# Altium schematic sheet Internal 2017-2018.SchDoc
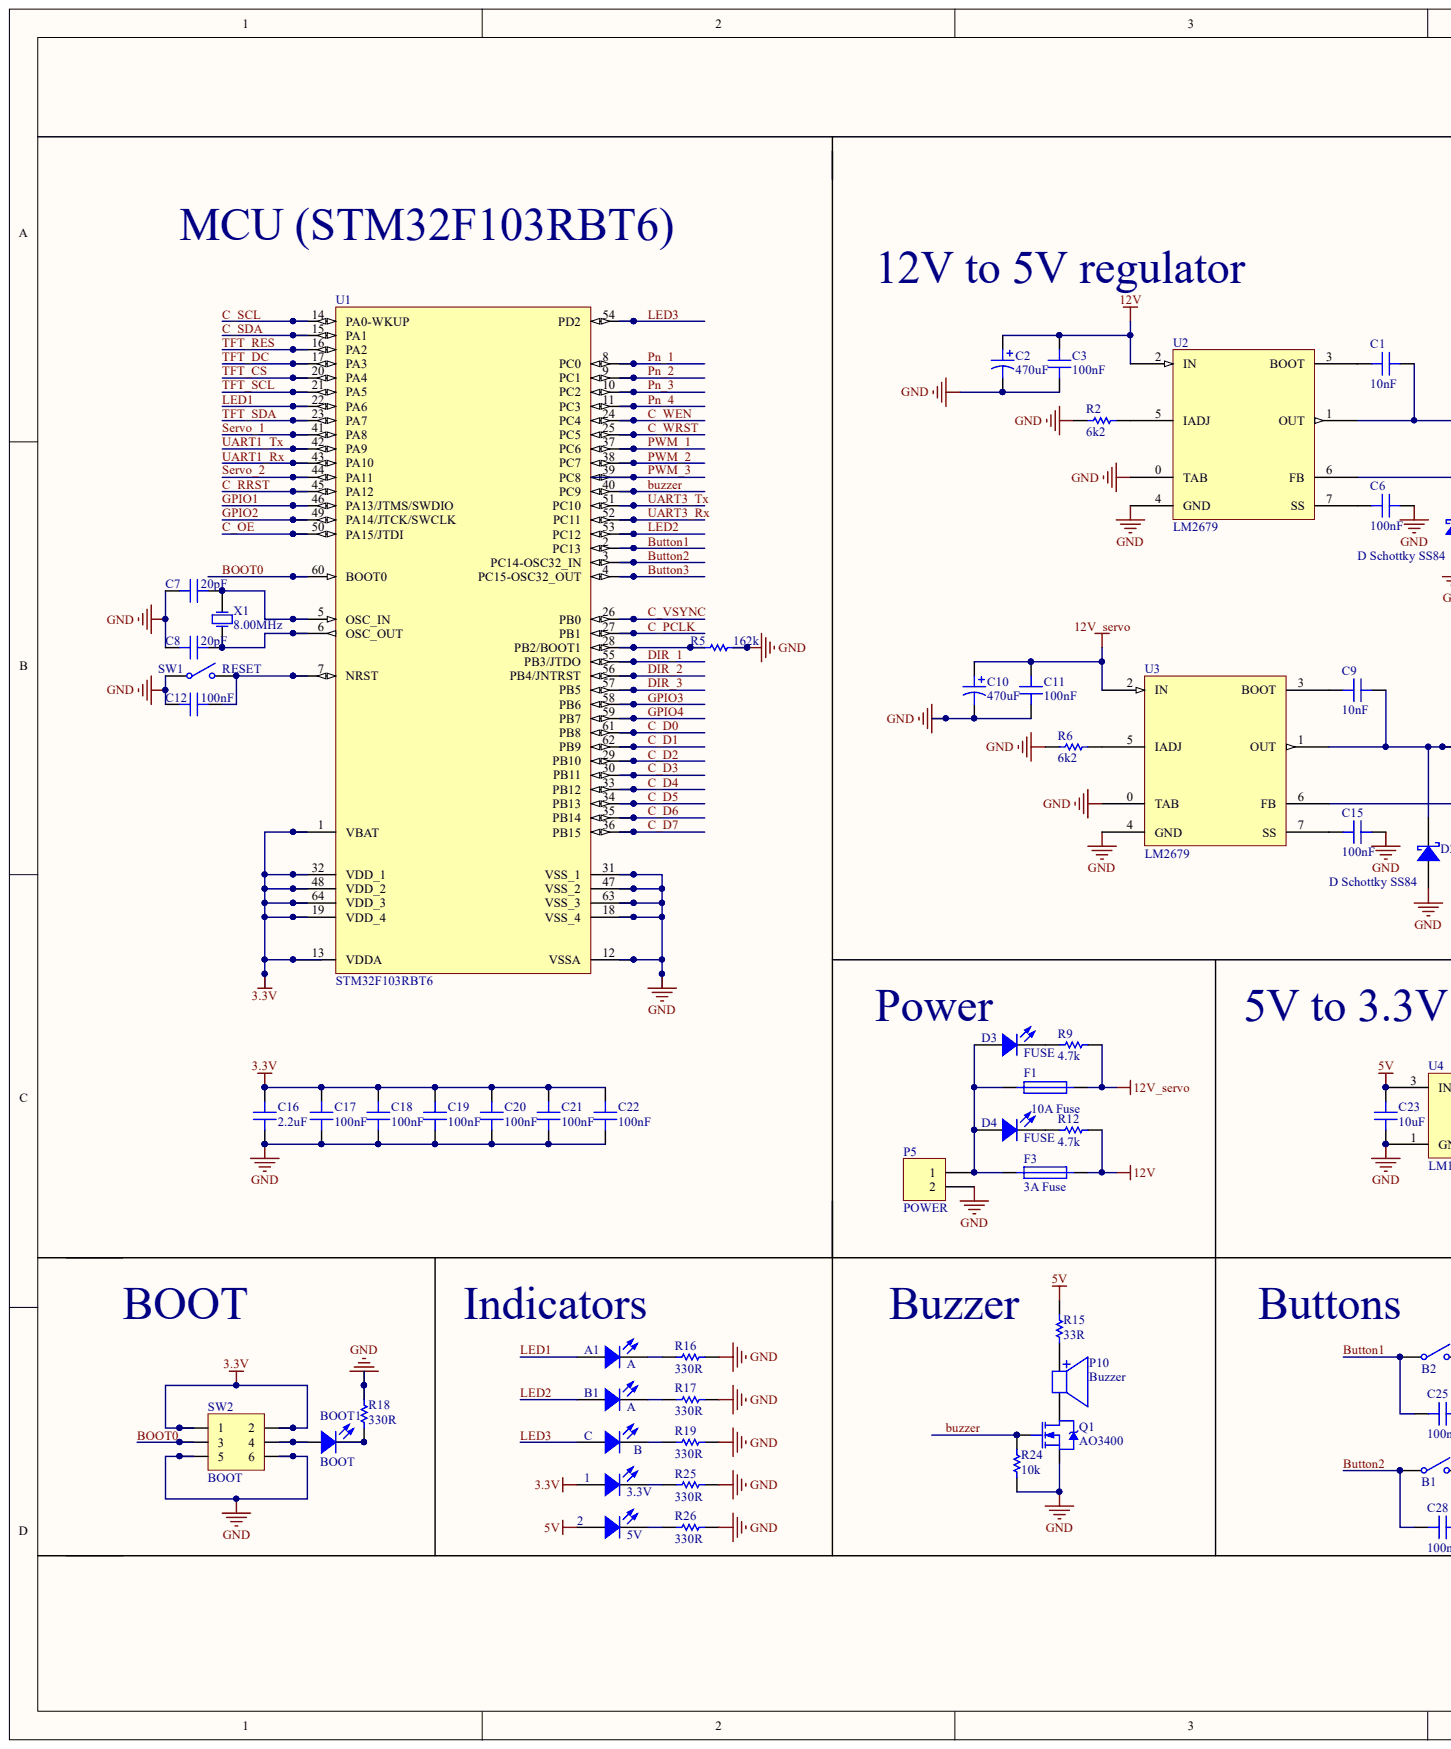
[diagram p0: Internal 2017-2018.SchDoc, left]
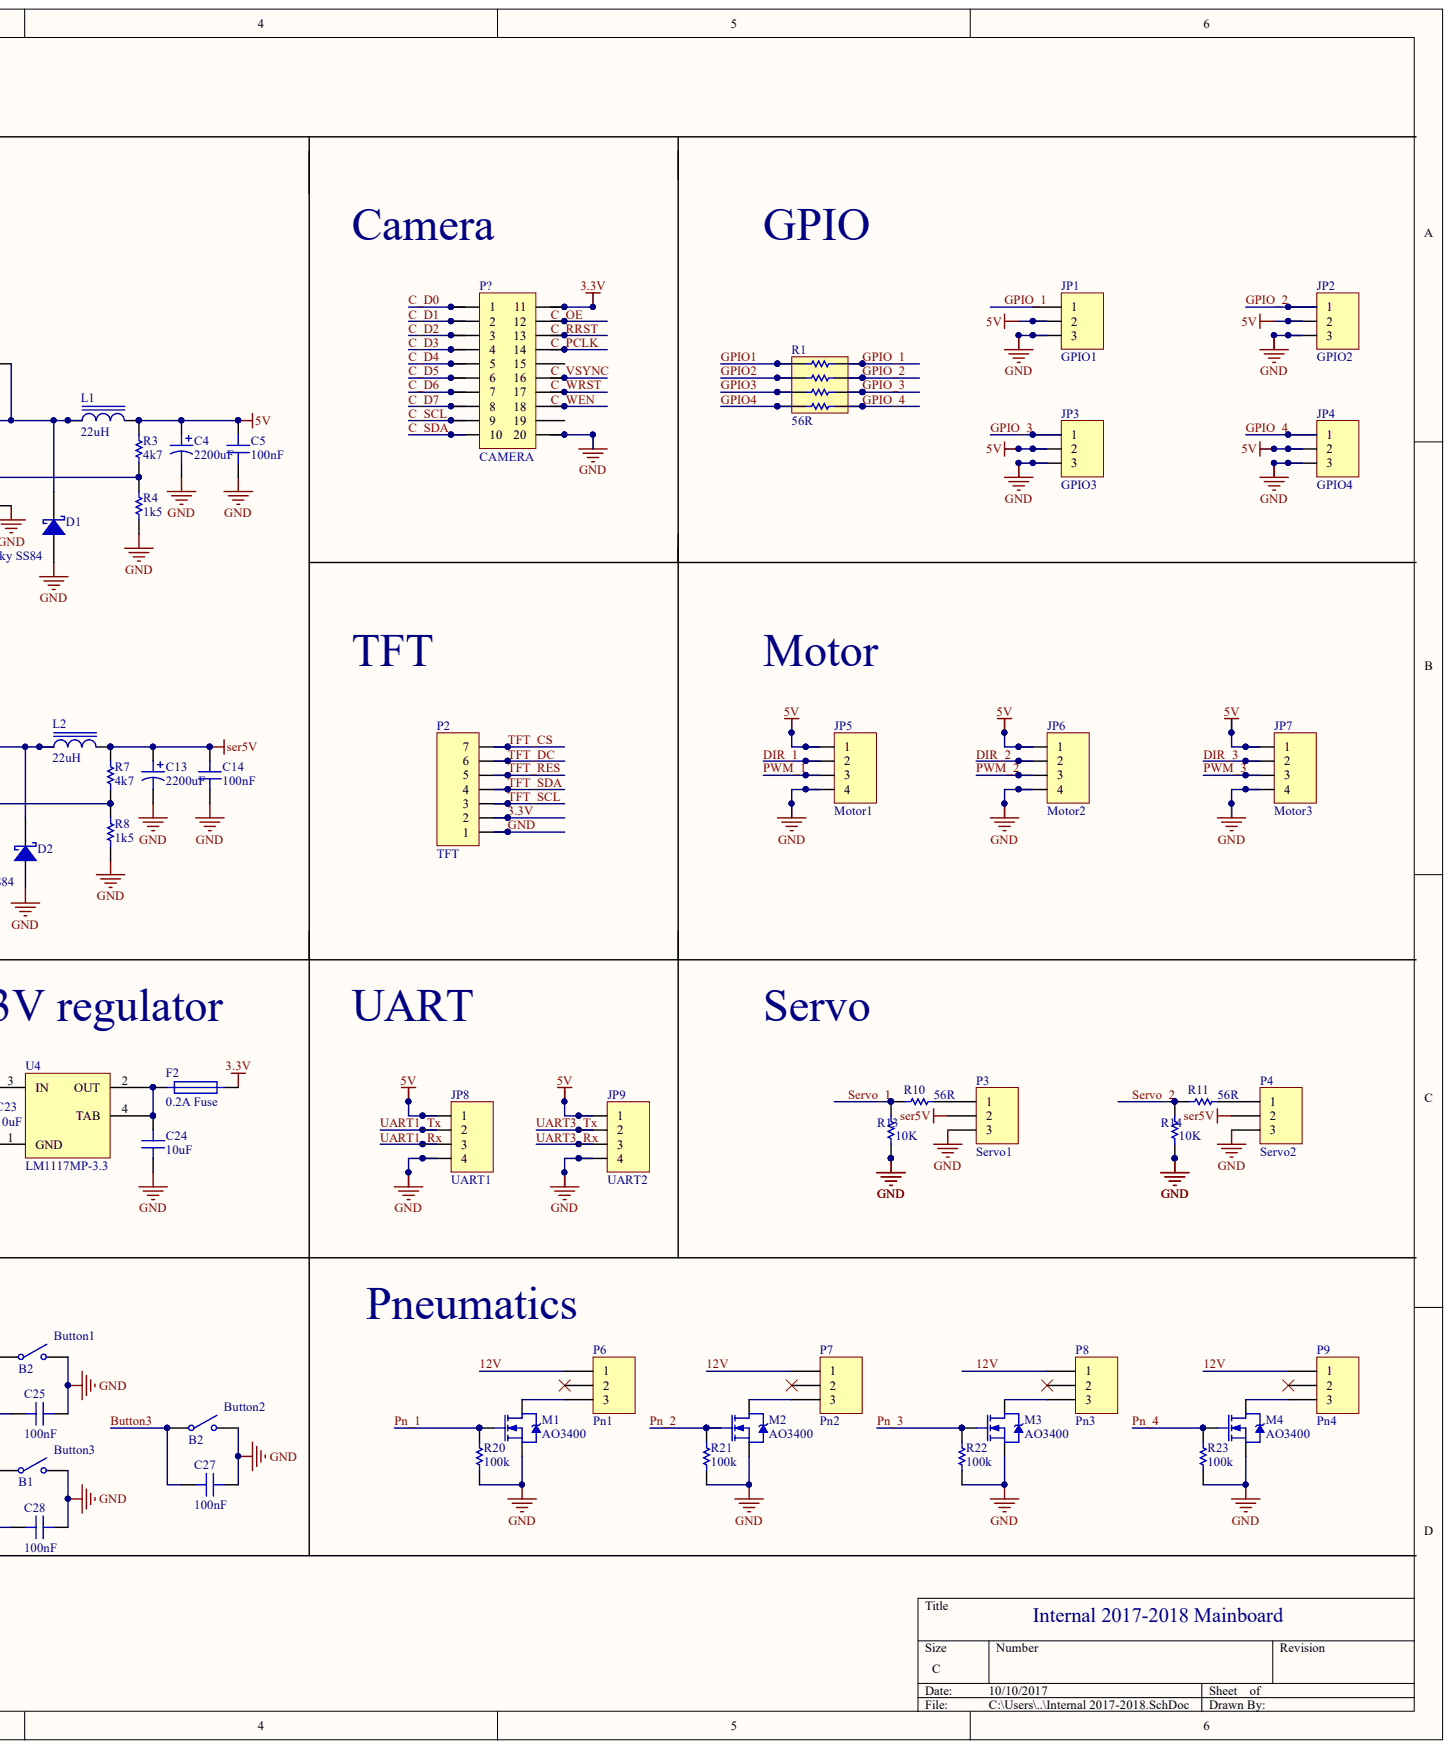
[diagram p1: Internal 2017-2018.SchDoc, right]

## Parts
1  3.3V  [D0805]
2  5V  [D0805]
A1  A  [D0805]
B1  A  [D0805]
BOOT1  BOOT  [D0805]
Button1  B2  [PUSH SWITCH]
Button2  B2  [PUSH SWITCH]
Button3  B1  [PUSH SWITCH]
C  B  [D0805]
C1  10nF  [CC2012-0805]
C2  470uF  [CAP10x12]
C3  100nF  [CC2012-0805]
C4  2200uF  [SV-H]
C5  100nF  [CC2012-0805]
C6  100nF  [CC2012-0805]
C7  20pF  [CC2012-0805]
C8  20pF  [CC2012-0805]
C9  10nF  [CC2012-0805]
C10  470uF  [CAP10x12]
C11  100nF  [CC2012-0805]
C12  100nF  [CC2012-0805]
C13  2200uF  [SV-H]
C14  100nF  [CC2012-0805]
C15  100nF  [CC2012-0805]
C16  2.2uF  [CC2012-0805]
C17  100nF  [CC2012-0805]
C18  100nF  [CC2012-0805]
C19  100nF  [CC2012-0805]
C20  100nF  [CC2012-0805]
C21  100nF  [CC2012-0805]
C22  100nF  [CC2012-0805]
C23  10uF  [CC2012-0805]
C24  10uF  [CC2012-0805]
C25  100nF  [CC2012-0805]
C27  100nF  [CC2012-0805]
C28  100nF  [CC2012-0805]
D1  D Schottky SS84  [SMC]
D2  D Schottky SS84  [SMC]
D3  FUSE  [D0805]
D4  FUSE  [D0805]
F1  10A Fuse  [FUSE SOCKET]
F2  0.2A Fuse  [CF3216-1206]
F3  3A Fuse  [FUSE SOCKET]
JP1  GPIO1  [LOCKEDHDR-3]
JP2  GPIO2  [LOCKEDHDR-3]
JP3  GPIO3  [LOCKEDHDR-3]
JP4  GPIO4  [LOCKEDHDR-3]
JP5  Motor1  [LOCKEDHDR-4]
JP6  Motor2  [LOCKEDHDR-4]
JP7  Motor3  [LOCKEDHDR-4]
JP8  UART1  [LOCKEDHDR-4]
JP9  UART2  [LOCKEDHDR-4]
L1  22uH  [CL3225-1210]
L2  22uH  [CL3225-1210]
M1  AO3400  [SOT-23]
M2  AO3400  [SOT-23]
M3  AO3400  [SOT-23]
M4  AO3400  [SOT-23]
P2  TFT  [HDR1X7]
P3  Servo1  [LOCKEDHDR-3]
P4  Servo2  [LOCKEDHDR-3]
P5  POWER  [T-CONNECTOR(M)]
P6  Pn1  [LOCKEDHDR-3]
P7  Pn2  [LOCKEDHDR-3]
P8  Pn3  [LOCKEDHDR-3]
P9  Pn4  [LOCKEDHDR-3]
P10  Buzzer  [BUZ-9.0]
P?  CAMERA  [HDR2X10_CEN]
Q1  AO3400  [SO-G3/Z3.3]
R1  56R  [ARC241-0603x4]
R2  6k2  [CR2012-0805]
R3  4k7  [CR2012-0805]
R4  1k5  [CR2012-0805]
R5  162k  [CR2012-0805]
R6  6k2  [CR2012-0805]
R7  4k7  [CR2012-0805]
R8  1k5  [CR2012-0805]
R9  4.7k  [CR2012-0805]
R10  56R  [CR2012-0805]
R11  56R  [CR2012-0805]
R12  4.7k  [CR2012-0805]
R13  10K  [CR2012-0805]
R14  10K  [CR2012-0805]
R15  33R  [CR2012-0805]
R16  330R  [CR2012-0805]
R17  330R  [CR2012-0805]
R18  330R  [CR2012-0805]
R19  330R  [CR2012-0805]
R20  100k  [CR2012-0805]
R21  100k  [CR2012-0805]
R22  100k  [CR2012-0805]
R23  100k  [CR2012-0805]
R24  10k  [CR2012-0805]
R25  330R  [CR2012-0805]
R26  330R  [CR2012-0805]
SW1  RESET  [PUSH SWITCH]
SW2  BOOT  [POWER SWITCH]
U1  STM32F103RBT6  [LQFP64_N]
U2  LM2679  [TO-263(7)]
U3  LM2679  [TO-263(7)]
U4  LM1117MP-3.3  [MP04A_N]
X1  8.00MHz  [BCY-W2/E4.7]

## Nets
5V: 2.1 C4.1 C5.1 C23.2 JP1.2 JP2.2 JP3.2 JP4.2 JP5.1 JP6.1 JP7.1 JP8.1 JP9.1 L1.2 R3.2 R15.2 U4.3(IN)
12V_SERVO: C10.1 C11.1 F1.2 R9.2 U3.2(IN)
SER5V: C13.1 C14.1 L2.2 P3.2 P4.2 R7.2
3.3V: 1.1 C16.1 C17.2 C18.2 C19.2 C20.2 C21.2 C22.2 F2.2 P2.2 P?.11 SW2.1 SW2.2 U1.1(VBAT) U1.13(VDDA) U1.19(VDD_4) U1.32(VDD_1) U1.48(VDD_2) U1.64(VDD_3)
12V: C2.1 C3.1 F3.2 P6.1 P7.1 P8.1 P9.1 R12.2 U2.2(IN)
BOOT0: SW2.3 U1.60(BOOT0)
BUTTON1: Button1.1 C25.2 U1.2(PC13)
BUTTON2: Button3.1 C28.2 U1.3(PC14-OSC32_IN)
BUTTON3: Button2.1 C27.2 U1.4(PC15-OSC32_OUT)
BUZZER: Q1.1 R24.2 U1.40(PC9)
C_D0: P?.1 U1.61(PB8)
C_D1: P?.2 U1.62(PB9)
C_D2: P?.3 U1.29(PB10)
C_D3: P?.4 U1.30(PB11)
C_D4: P?.5 U1.33(PB12)
C_D5: P?.6 U1.34(PB13)
C_D6: P?.7 U1.35(PB14)
C_D7: P?.8 U1.36(PB15)
C_OE: P?.12 U1.50(PA15/JTDI)
C_PCLK: P?.14 U1.27(PB1)
C_RRST: P?.13 U1.45(PA12)
C_SCL: P?.9 U1.14(PA0-WKUP)
C_SDA: P?.10 U1.15(PA1)
C_VSYNC: P?.16 U1.26(PB0)
C_WEN: P?.18 U1.24(PC4)
C_WRST: P?.17 U1.25(PC5)
DIR_1: JP5.2 U1.55(PB3/JTDO)
DIR_2: JP6.2 U1.56(PB4/JNTRST)
DIR_3: JP7.2 U1.57(PB5)
GND: Button1.2 Button2.2 Button3.2 C2.2 C3.2 C4.2 C5.2 C6.2 C7.1 C8.1 C10.2 C11.2 C12.2 C13.2 C14.2 C15.2 C16.2 C17.1 C18.1 C19.1 C20.1 C21.1 C22.1 C23.1 C24.1 C25.1 C27.1 C28.1 D1.1 D2.1 JP1.3 JP2.3 JP3.3 JP4.3 JP5.4 JP6.4 JP7.4 JP8.4 JP9.4 M1.2 M2.2 M3.2 M4.2 P2.1 P3.3 P4.3 P5.2 P?.20 Q1.2 R2.1 R4.1 R5.2 R6.1 R8.1 R13.1 R14.1 R16.2 R17.2 R18.2 R19.2 R20.2 R21.2 R22.2 R23.2 R24.1 R25.2 R26.2 SW1.1 SW2.5 SW2.6 U1.12(VSSA) U1.18(VSS_4) U1.31(VSS_1) U1.47(VSS_2) U1.63(VSS_3) U2.0(TAB) U2.4(GND) U3.0(TAB) U3.4(GND) U4.1(GND)
GPIO1: R1.1 U1.46(PA13/JTMS/SWDIO)
GPIO2: R1.3 U1.49(PA14/JTCK/SWCLK)
GPIO3: R1.5 U1.58(PB6)
GPIO4: R1.7 U1.59(PB7)
GPIO_1: JP1.1 R1.2
GPIO_2: JP2.1 R1.4
GPIO_3: JP3.1 R1.6
GPIO_4: JP4.1 R1.8
LED1: A1.1 U1.22(PA6)
LED2: B1.1 U1.53(PC12)
LED3: C.1 U1.54(PD2)
PN_1: M1.1 R20.1 U1.8(PC0)
PN_2: M2.1 R21.1 U1.9(PC1)
PN_3: M3.1 R22.1 U1.10(PC2)
PN_4: M4.1 R23.1 U1.11(PC3)
PWM_1: JP5.3 U1.37(PC6)
PWM_2: JP6.3 U1.38(PC7)
PWM_3: JP7.3 U1.39(PC8)
SERVO_1: R10.1 R13.2 U1.41(PA8)
SERVO_2: R11.1 R14.2 U1.44(PA11)
TFT_CS: P2.7 U1.20(PA4)
TFT_DC: P2.6 U1.17(PA3)
TFT_RES: P2.5 U1.16(PA2)
TFT_SCL: P2.3 U1.21(PA5)
TFT_SDA: P2.4 U1.23(PA7)
UART1_RX: JP8.3 U1.43(PA10)
UART1_TX: JP8.2 U1.42(PA9)
UART3_RX: JP9.3 U1.52(PC11)
UART3_TX: JP9.2 U1.51(PC10)
Net1_2: 1.2 R25.1
Net2_2: 2.2 R26.1
NetA1_2: A1.2 R16.1
NetB1_2: B1.2 R17.1
NetBOOT1_1: BOOT1.1 SW2.4
NetBOOT1_2: BOOT1.2 R18.1
NetC1_1: C1.1 U2.3(BOOT)
NetC1_2: C1.2 D1.2 L1.1 U2.1(OUT)
NetC6_1: C6.1 U2.7(SS)
NetC7_2: C7.2 U1.5(OSC_IN) X1.2
NetC8_2: C8.2 U1.6(OSC_OUT) X1.1
NetC9_1: C9.1 U3.3(BOOT)
NetC9_2: C9.2 D2.2 L2.1 U3.1(OUT)
NetC12_1: C12.1 SW1.2 U1.7(NRST)
NetC15_1: C15.1 U3.7(SS)
NetC24_2: C24.2 F2.1 U4.2(OUT) U4.4(TAB)
NetC_2: C.2 R19.1
NetD3_1: D3.1 D4.1 F1.1 F3.1 P5.1
NetD3_2: D3.2 R9.1
NetD4_2: D4.2 R12.1
NetM1_3: M1.3 P6.3
NetM2_3: M2.3 P7.3
NetM3_3: M3.3 P8.3
NetM4_3: M4.3 P9.3
NetP3_1: P3.1 R10.2
NetP4_1: P4.1 R11.2
NetP10_1: P10.1 R15.1
NetP10_2: P10.2 Q1.3
NetR2_2: R2.2 U2.5(IADJ)
NetR3_1: R3.1 R4.2 U2.6(FB)
NetR5_1: R5.1 U1.28(PB2/BOOT1)
NetR6_2: R6.2 U3.5(IADJ)
NetR7_1: R7.1 R8.2 U3.6(FB)

Not connected: P6 2; P7 2; P8 2; P9 2; P? 15 19

## Library data of the parts
1 2 A1 B1 BOOT1 C D3 D4 = LED: Typical RED GaAs LED; Component Kind: Standard; LatestRevisionDate: 08-Jul-2005; LatestRevisionNote: Mech Layer 1 removed.; Library Name: Robocon_BJT.IntLib; PackageReference: LED-1; Published: 8-Jun-2000; Publisher: Altium Limited
Button1-Button3 SW1 = SW-SPST: Single-Pole, Single-Throw Switch; Component Kind: Standard; Library Name: Robocn_connectors.IntLib; Published: 24-Mar-1999; Revision: July-2002: Re-released for DXP Platform.; Publisher: Altium Limited
C1 C3 C5-C9 C11 C12 C14-C25 C27 C28 = Cap Semi: Capacitor (Semiconductor SIM Model); Component Kind: Standard; LatestRevisionDate: 17-Jul-2002; LatestRevisionNote: Re-released for DXP Platform.; Library Name: Robocon_RCL.IntLib; PackageReference: C1206; Published: 8-Jun-2000; Signal Integrity: Cap Semi; Publisher: Altium Limited
C2 C10 = Cap Pol DIP: Polarized Capacitor (Radial); Component Kind: Standard; LatestRevisionDate: 17-Jul-2002; LatestRevisionNote: Re-released for DXP Platform.; Library Name: Robocon_RCL.IntLib; Published: 8-Jun-2000; Publisher: Altium Limited
C4 C13 = Cap Pol SMD: Polarized Capacitor (Axial); Component Kind: Standard; LatestRevisionDate: 17-Jul-2002; LatestRevisionNote: Re-released for DXP Platform.; Library Name: Robocon_RCL.IntLib; Published: 8-Jun-2000; Publisher: Altium Limited
D1 D2 = D Schottky: Schottky Diode; Code - JEDEC: DO-214-AA; Component Kind: Standard; Library Name: Robocon_BJT.IntLib; Published: 8-Jun-2000; Revision: July-2002: Re-released for DXP Platform.; Publisher: Altium Limited
F1-F3 = Fuse: Component Kind: Standard; Description: Fuse; Library Name: Robocon_RCL.IntLib; Published: 8-Jun-2000; Revision: July-2002: Re-released for DXP Platform.; Publisher: Altium Limited
JP1-JP4 P3 P4 P6-P9 = Header 3: Header, 3-Pin; Component Kind: Standard; Library Name: Robocn_connectors.IntLib; Revision: 17-Jul-2002: Re-released for DXP Platform.; Signal Integrity: Connector; Publisher: Altium Limited
JP5-JP9 = Header 4: Header, 4-Pin; Component Kind: Standard; Library Name: Robocn_connectors.IntLib; Revision: 17-Jul-2002: Re-released for DXP Platform.; Signal Integrity: Connector; Publisher: Altium Limited
L1 L2 = Inductor: Value: 10uH; Component Kind: Standard; Description: Inductor; Library Name: Robocon_RCL.IntLib; Published: 8-Jun-2000; Revision: July-2002: Re-released for DXP Platform.; Publisher: Altium Limited
M1-M4 Q1 = AO3400: N-Channel MOSFET; Component Kind: Standard; Library Name: Robocon_BJT.IntLib; Published: 8-Jun-2000; Revision: July-2002: Re-released for DXP Platform.; Publisher: Altium Limited
P2 = Header 7: Header, 6-Pin; Component Kind: Standard; LatestRevisionDate: 17-Jul-2002; LatestRevisionNote: Re-released for DXP Platform.; Library Name: Robocn_connectors.IntLib; Publisher: Altium Limited
P5 = Header 2: Header, 2-Pin; Component Kind: Standard; Library Name: Robocn_connectors.IntLib; Revision: 17-Jul-2002: Re-released for DXP Platform.; Signal Integrity: Connector; Publisher: Altium Limited
P? = Header 10X2A: Header, 10-Pin, Dual row; Component Kind: Standard; LatestRevisionDate: 17-Jul-2002; LatestRevisionNote: Re-released for DXP Platform.; Library Name: Miscellaneous Connectors.IntLib; Signal Integrity: Connector; Publisher: Altium Limited
R1 = Res Array: 4x Resistor Array; Component Kind: Standard; LatestRevisionDate: 17-Jul-2002; LatestRevisionNote: Re-released for DXP Platform.; Library Name: Robocon_RCL.IntLib; Published: 8-Jun-2000; Signal Integrity: Res Semi; Publisher: Altium Limited
R2-R26 = Res Semi: Semiconductor Resistor; Component Kind: Standard; LatestRevisionDate: 17-Jul-2002; LatestRevisionNote: Re-released for DXP Platform.; Library Name: Robocon_RCL.IntLib; Published: 8-Jun-2000; Signal Integrity: Res Semi; Publisher: Altium Limited
  R20-R23: Simulation: RES
SW2 = Header 3X2: Header, 3-Pin, Dual row; Component Kind: Standard; Library Name: Robocn_connectors.IntLib; Revision: 17-Jul-2002: Re-released for DXP Platform.; Signal Integrity: Connector; Publisher: Altium Limited
U1 = STM32F103RBT6: STM32 ARM-based 32-bit MCU with 128 Kbytes Flash, 64-pin LQFP, Industrial Temperature; Component Kind: Standard; ComponentLink1Description: Manufacturer Link; ComponentLink1URL: www.st.com/stm32; ComponentLink2Description: Datasheet; ComponentLink2URL: http://www.st.com/stonline/products/literature/ds/13587.pdf; DatasheetVersion: rev6, Mar-2008; Library Name: Robocon_IC.IntLib; PackageDescription: 64-Pin Low-Profile Quad Flat Pack, 10 x 10 mm Body, 0.5 mm Pitch (LQFP64); PackageReference: LQFP64; PackageVersion: Mar-2008; Published: 30-May-2008; Publisher: Altium Limited
U2 U3 = LM2679: 5A Step-Down Switching Mode Voltage Regulator; Component Kind: Standard; Library Name: Robocon_IC.IntLib
U4 = LM1117MP-3.3: 800mA Low-Dropout Linear Regulator; Manufacturer: National Semiconductor; CompType: LDO IC; PackageTopMarking: XYTT*N05A; Code_IPC: SOT223-4; Code_JEDEC: TO-261-AA; Component Kind: Standard; ComponentLink1Description: LM1117 Datasheet; ComponentLink1URL: http://www.national.com/ds/LM/LM1117.pdf; ComponentLink2Description: LM1117 Web Page; ComponentLink2URL: http://www.national.com/pf/LM/LM1117.html; ComponentLink3Description: MP04A Package Page; ComponentLink3URL: http://www.national.com/packaging/folders/mp04a.html; DatasheetVersion: Apr-2006; DigiKeyPartNumber: LM1117MP-3.3CT-ND; Library Name: Robocon_IC.IntLib; PackageDescription: Molded SOT-223, 6.50 x 3.56 x 1.6mm Body, 4 Lead, 2.3mm Pitch; PackageReference: MP04A; PackageVersion: revB, Apr-2003; PartNumber: LM1117MP-3.3; PinCount: 4; Published: 27-Nov-2008; RoHS: O; Publisher: Altium Limited
X1 = XTAL: Crystal Oscillator; Component Kind: Standard; Library Name: Robocon_RCL.IntLib; Published: 8-Jun-2000; Revision: July-2002: Re-released for DXP Platform.; Publisher: Altium Limited
P10 = Buzzer: Component Kind: Standard; Library Name: Robocon_RCL.IntLib
